# Altium schematic sheet schematic.SchDoc
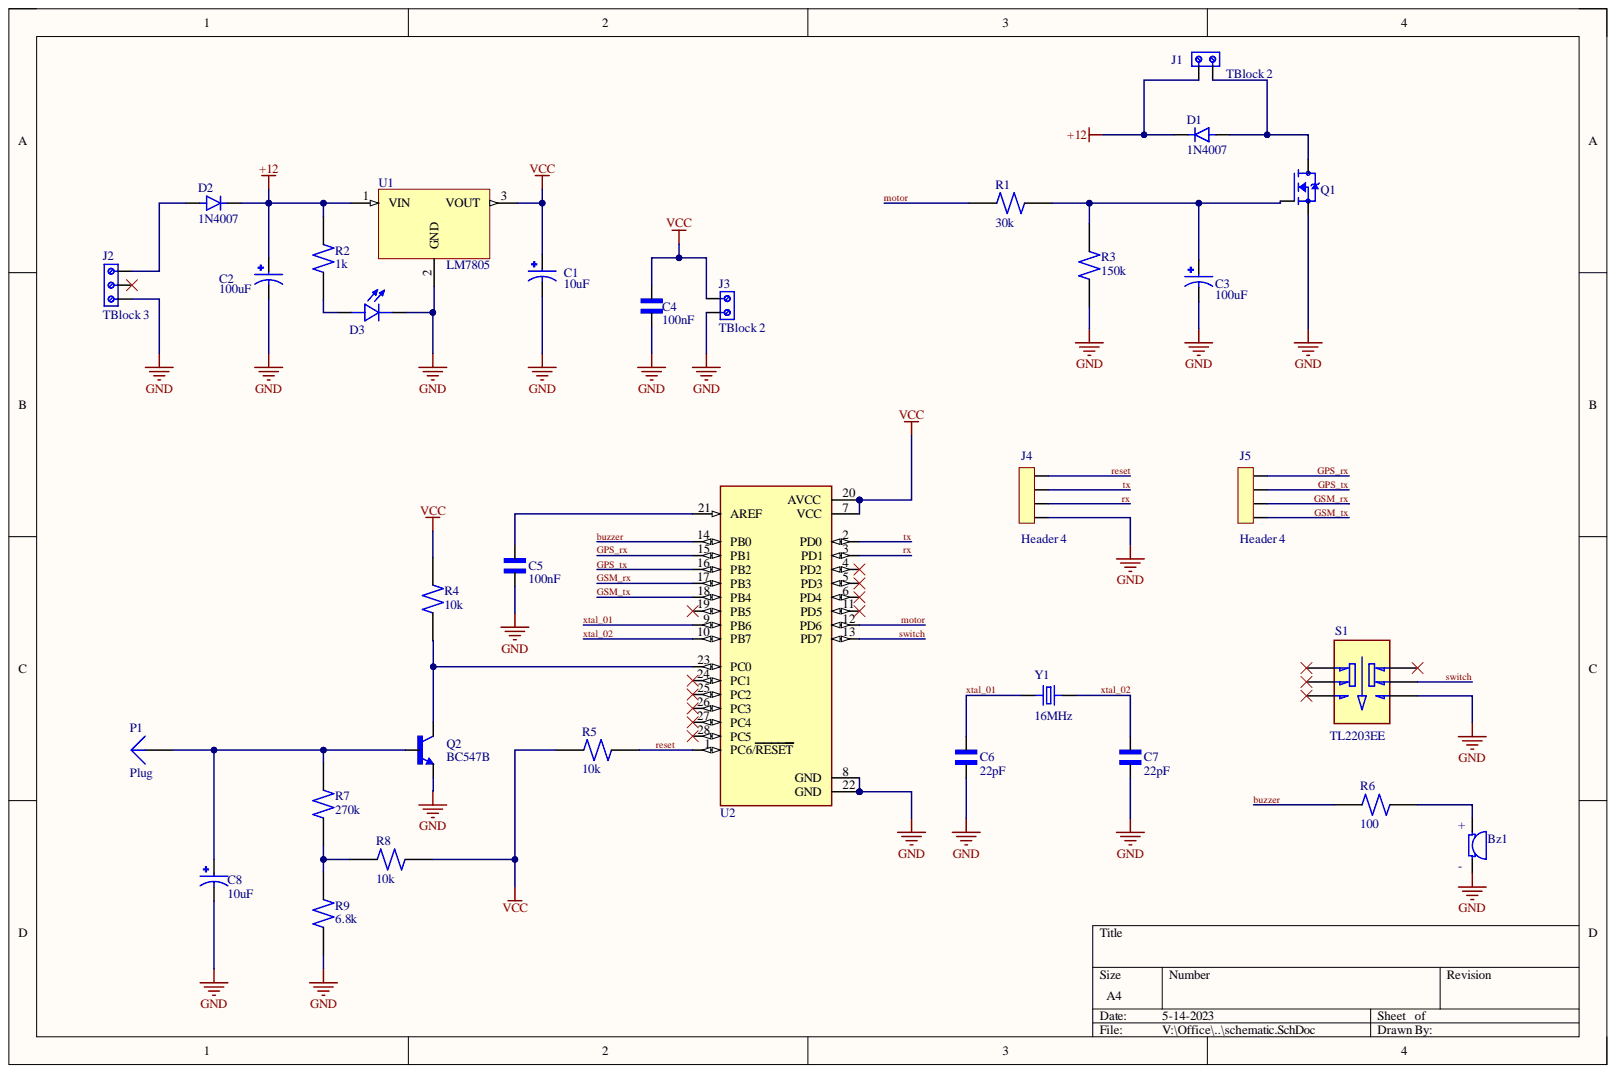
[diagram p0: schematic.SchDoc]

## Parts
Bz1  AI-1223-TWT-12V-R  [XDCR_AI-1223-TWT-12V-R]  (value not drawn)
C1  10uF  [CAPPRD500W50D500H1100]
C2  100uF  [CAPPRD500W50D500H1100]
C3  100uF  [CAPPRD500W50D500H1100]
C4  100nF  [CAPRB500W50L450T300H550]
C5  100nF  [CAPRB500W50L450T300H550]
C6  22pF  [CAPRB500W50L450T300H550]
C7  22pF  [CAPRB500W50L450T300H550]
C8  10uF  [CAPPRD500W50D500H1100]
D1  1N4007  [DIOAD1060W80L520D270]
D2  1N4007  [DIOAD1060W80L520D270]
D3  L08R5000Q1  [LEDRD254W57D500H1070]  (value not drawn)
J1  TBlock 2  [691111710002]
J2  TBlock 3  [691214110003]
J3  TBlock 2  [691111710002]
J4  Header 4  [SULLINS_PPTC041LFBN-RC]
J5  Header 4  [SULLINS_PPTC041LFBN-RC]
P1  Plug  [PIN1]
Q1  N-Channel mosfet  [TO254P1052X465X1989-3]  (value not drawn)
Q2  BC547B  [TO92250P510H770-3]
R1  30k  [RESAD1100W55L680D260]
R2  1k  [RESAD1100W55L680D260]
R3  150k  [RESAD1100W55L680D260]
R4  10k  [RESAD1100W55L680D260]
R5  10k  [RESAD1100W55L680D260]
R6  100  [RESAD1100W55L680D260]
R7  270k  [RESAD1100W55L680D260]
R8  10k  [RESAD1100W55L680D260]
R9  6.8k  [RESAD1100W55L680D260]
S1  TL2203EE  [SW_TL2203EE]
U1  LM7805  [TO255P1020X450X1968-3]
U2  ATMEGA328P-PU  [DIP794W46P254L2967H457Q28B]  (value not drawn)
Y1  16MHz  [XTAL_ECS-160-20-4X]

## Nets
+12: C2.P D1.C D2.C J1.2 R2.2 U1.1
GND: Bz1.N C1.N C2.N C3.N C4.2 C5.2 C6.2 C7.2 C8.N D3.C J2.3 J3.1 J4.4 Q1.3 Q2.3 R3.1 R9.1 S1.6 U1.2 U2.8(GND) U2.22(GND)
VCC: C1.P C4.1 J3.2 R4.2 R5.2 R8.1 U1.3 U2.7(VCC) U2.20(AVCC)
buzzer: R6.2 U2.14(PB0)
GPS_rx: J5.1 U2.15(PB1)
GPS_tx: J5.2 U2.16(PB2)
GSM_rx: J5.3 U2.17(PB3)
GSM_tx: J5.4 U2.18(PB4)
motor: R1.1 U2.12(PD6)
reset: J4.1 R5.1 U2.1(PC6/RESET)
rx: J4.3 U2.3(PD1)
switch: S1.5 U2.13(PD7)
tx: J4.2 U2.2(PD0)
xtal_01: C6.1 U2.9(PB6) Y1.1
xtal_02: C7.1 U2.10(PB7) Y1.2
NetBz1_P: Bz1.P R6.1
NetC3_P: C3.P Q1.1 R1.2 R3.2
NetC5_1: C5.1 U2.21(AREF)
NetC8_P: C8.P P1.1 Q2.2 R7.2
NetD1_A: D1.A J1.1 Q1.2
NetD2_A: D2.A J2.1
NetD3_A: D3.A R2.1
NetQ2_1: Q2.1 R4.1 U2.23(PC0)
NetR7_1: R7.1 R8.2 R9.2

Not connected: J2 2; S1 1 2 3 4; U2 4(PD2) 5(PD3) 6(PD4) 11(PD5) 19(PB5) 24(PC1) 25(PC2) 26(PC3) 27(PC4) 28(PC5)

## Library data of the parts
Bz1 = Buzzer: ELECTRO-MECHANICAL AUDIO INDICATOR; MF: PUI Audio,; Availability: In Stock; Component Kind: Standard; MP: AI-1223-TWT-12V-R; Package: 2225 PUI Audio; Price: None; Purchase-URL: https://pricing.snapeda.com/search/part/AI-1223-TWT-12V-R/?ref=eda; Library Name: Installed library.IntLib
C1-C3 C8 = Electrolytic capacitor: FS-A; MF: {'manufacturer': u'Panasonic'}; MP: EEUFS0J221B; Availability: Unavailable; Component Kind: Standard; Package: None; Price: None; Library Name: Installed library.IntLib
C4-C7 = Ceramic capacitor: 2200 pF Â±10% 250V Ceramic Capacitor X7R Radial; MF: TDK; MP: FG24X7R2E222KNT06; Check_prices: https://www.snapeda.com/parts/FG24X7R2E222KNT06/TDK/view-part/?ref=eda; Component Kind: Standard; Digi-Key_Part_Number: 445-173386-1-ND; Package: Radial TDK; Purchase-URL: https://pricing.snapeda.com/search/part/FG24X7R2E222KNT06/?ref=eda; SnapEDA_Link: https://www.snapeda.com/parts/FG24X7R2E222KNT06/TDK/view-part/?ref=snap; Library Name: Installed library.IntLib
D1 D2 = 1N4007: Diode Switching 1KV 1A 2-Pin DO-41; Availability: Unavailable; Component Kind: Standard; Library Name: Devices.IntLib; MP: 1N4007; Package: None; Price: None
D3 = LED: LED, Orange, Through Hole, T-1 3/4 (5mm), 30 mA, 2.1 V, 635 nm; MF: {'manufacturer': u'LED Technology'}; Availability: Unavailable; Component Kind: Standard; MP: L08R5000Q1; Package: None; Price: None; Library Name: Installed library.IntLib
J1 J3 = Terminal Block - 2Pins: 2 Position Wire to Board Terminal Block Horizontal with Board 0.197 _5.00mm_ Through Hole; MF: Wurth Electronics; Availability: Unavailable; Component Kind: Standard; MP: 691111710002; Package: None; Price: None; SnapEDA_Link: https://www.snapeda.com/parts/691111710002/Wurth%20Electronics%20Inc./view-part/1195092/?ref=snap; Library Name: Installed library.IntLib
J2 = Terminal blocks - 3Pins (Small): 3 Position Wire to Board Terminal Block Horizontal with Board 0.138 (3.50mm) Through Hole; MF: Wurth Elektronik; Availability: In Stock; Check_prices: https://www.snapeda.com/parts/691214110003/Wurth+Elektronik/view-part/?ref=eda; Component Kind: Standard; MP: 691214110003; Package: None; Price: None; Purchase-URL: https://pricing.snapeda.com/search/part/691214110003/?ref=eda; SnapEDA_Link: https://www.snapeda.com/parts/691214110003/Wurth+Elektronik/view-part/?ref=snap; Library Name: Installed library.IntLib
J4 J5 = Female header - 4Pins: 4 Position Header Connector Through Hole; MF: Sullins Connector; MP: PPTC041LFBN-RC; Availability: Warning; Check_prices: https://www.snapeda.com/parts/PPTC041LFBN-RC/Sullins%20Connector%20Solutions/view-part/285917/?ref=eda; Component Kind: Standard; Package: None; Price: None; Purchase-URL: https://pricing.snapeda.com/search/part/PPTC041LFBN-RC/?ref=eda; SnapEDA_Link: https://www.snapeda.com/parts/PPTC041LFBN-RC/Sullins%20Connector%20Solutions/view-part/285917/?ref=snap; Library Name: Installed library.IntLib
Q1 = IRF540: N-Channel 100V 22A (Tc) 85W (Tc) Through Hole TO-220AB; Availability: Unavailable; Component Kind: Standard; MP: IRF540; Package: None; Price: None; Library Name: Installed library.IntLib
Q2 = BC547B: <a href="https://pricing.snapeda.com/parts/BC337-16%20A1/Taiwan%20Semiconductor/view-part?ref=eda">Check availability</a> <a href="https://pricing.snapeda.com/parts/BC337-16%20A1/Taiwan%20Semiconductor/view-part?ref=eda">Check availability</a>; Availability: Unavailable; Component Kind: Standard; MP: BC547B; Package: None; Price: None; Library Name: Installed library.IntLib
R1-R9 = Resistor (0.25W): (MFR-25FBF52-10K) 10,2 kOhm bei 1% 0,25W, 1/4W Durchbohrlochwiderstand Axial Metal Film; MF: Yageo; Component Kind: Standard; Digi-Key_Part_Number: 10.2KXBK-ND; MP: MFR-25FBF52-10K; Package: Axial Yageo; Purchase-URL: https://pricing.snapeda.com/search/part/MFR-25FBF52-10K/?ref=eda; SnapEDA_Link: https://www.snapeda.com/parts/MFR-25FBF52-10K/Yageo/view-part/424333/?ref=snap; Library Name: Installed library.IntLib
S1 = Switch button: Pushbutton Switch DPDT Standard Through Hole; MF: E-Switch; Availability: In Stock; Check_prices: https://www.snapeda.com/parts/TL2203EE/E-Switch/view-part/?ref=eda; Component Kind: Standard; MP: TL2203EE; Package: None; Price: None; Purchase-URL: https://pricing.snapeda.com/search/part/TL2203EE/?ref=eda; SnapEDA_Link: https://www.snapeda.com/parts/TL2203EE/E-Switch/view-part/?ref=snap; Library Name: Installed library.IntLib
U1 = LM78__: MP: LM7805CT/NOPB; Availability: Unavailable; Component Kind: Standard; Library Name: Voltage regulator.IntLib; Package: None; Price: None
U2 = ATMEGA328P-PU: ATmega Series 20 MHz 32 KB Flash 2 KB SRAM 8-Bit Microcontroller - DIP-28; Availability: Unavailable; Component Kind: Standard; MP: ATMEGA328P-PU; Package: None; Price: None; Library Name: Installed library.IntLib
Y1 = Crystal oscilator: CRYSTAL T/H; MF: {'manufacturer': u'ECS Inc.'}; MP: ECS-160-S-4; Availability: Unavailable; Component Kind: Standard; Package: None; Price: None; Library Name: Installed library.IntLib
P1 = Plug: Component Kind: Standard; Description: Plug; LatestRevisionDate: 17-Jul-2002; LatestRevisionNote: Re-released for DXP Platform.; Library Name: Miscellaneous Connectors.IntLib; Signal Integrity: Connector; Publisher: Altium Limited
